# Altium schematic sheet PS.SchDoc
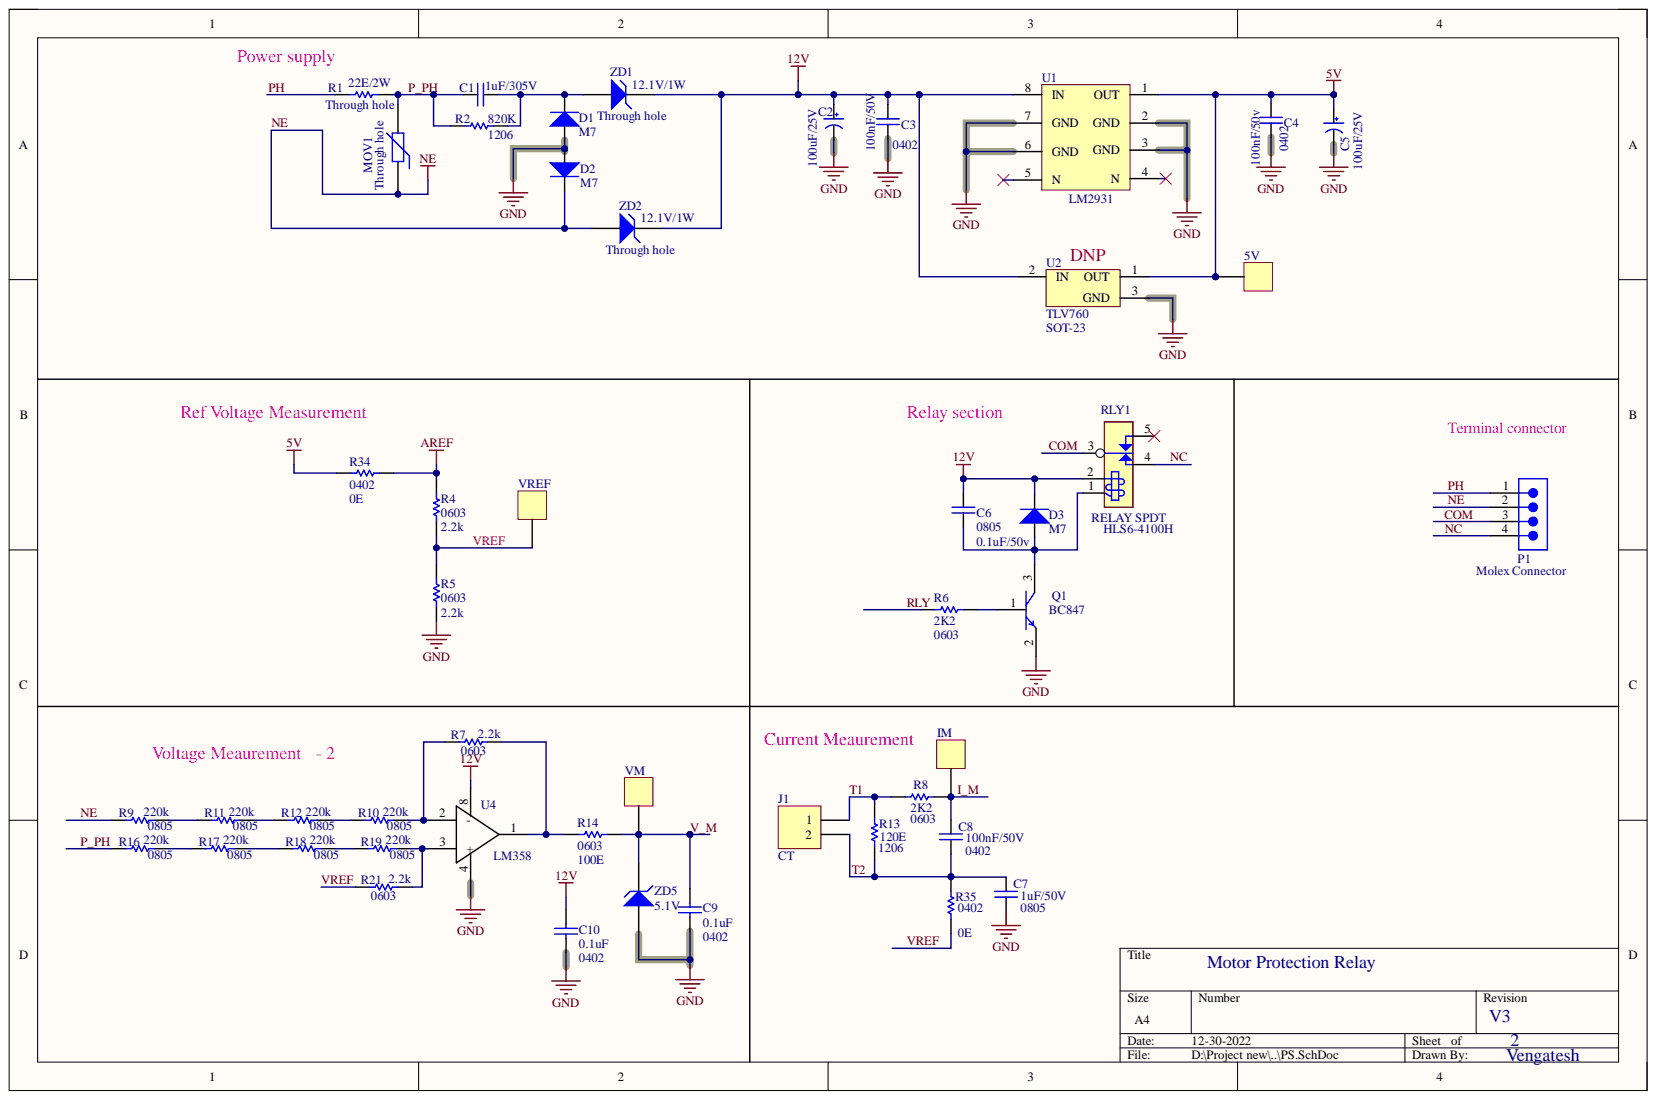
[diagram p0: PS.SchDoc]

## Parts
5V  Test pad  [Test Pad]  (value not drawn)
C1  value 1uF/305V  [Capacitor Y2-22.5mm_01]  (hidden comment: Cap)
C2  value 100uF/25V  [CAPPR2.5-6.3x7]  (hidden comment: Electrolytic Capacitor)
C3  value 100nF/50V  Package: 0402  [C_0402]  (hidden comment: Cap)
C4  value 100nF/50v  Package: 0402  [C_0402]  (hidden comment: Cap)
C5  value 100uF/25V  [CAPPR2.5-6.3x7]  (hidden comment: Electrolytic Capacitor)
C6  value 0.1uF/50v  Package: 0805  [C_0805]  (hidden comment: Cap)
C7  value 1uF/50V  Package: 0805  [0805-rc]  (hidden comment: Cap)
C8  value 100nF/50V  Package: 0402  [C_0402]  (hidden comment: Cap)
C9  value 0.1uF  Package: 0402  [C_0402]  (hidden comment: Cap)
C10  value 0.1uF  Package: 0402  [C_0402]  (hidden comment: Cap)
D1  value M7  [SMA-DIODE]  (hidden comment: diode)
D2  value M7  [SMA-DIODE]  (hidden comment: diode)
D3  value M7  [SMA-DIODE]  (hidden comment: diode)
IM  Test pad  [Test Pad]  (value not drawn)
J1  CT  [Molex_SPOX-5267_22-03-5025_02x2.54mm_Straight]
MOV1  MOV  Package: Through hole  [Mov - 3 Pin]
P1  645004114822  [645004114822]  (value not drawn)
Q1  value BC847  MFG no: BC847  [SOT-23]  (hidden comment: Transistor)
R1  value 22E/2W  Package: Through hole  [RESISTOR 1/2W]  (hidden comment: Res1)
R2  value 820K  Package: 1206  [R_1206]  (hidden comment: Res1)
R4  value 2.2k  Package: 0603  [0603-RC]  (hidden comment: 47R 5% 0805)
R5  value 2.2k  Package: 0603  [0603-RC]  (hidden comment: 47R 5% 0805)
R6  value 2K2  Package: 0603  [0603-RC]  (hidden comment: Res1)
R7  value 2.2k  Package: 0603  [0603-RC]
R8  value 2K2  [0603-RC]
R9  value 220k  Package: 0805  [R_0805]
R10  value 220k  Package: 0805  [R_0805]
R11  value 220k  Package: 0805  [R_0805]
R12  value 220k  Package: 0805  [R_0805]
R13  value 120E  Package: 1206  [1206-RC]
R14  value 100E  Package: 0603  [0603-RC]
R16  value 220k  Package: 0805  [R_0805]
R17  value 220k  Package: 0805  [R_0805]
R18  value 220k  Package: 0805  [R_0805]
R19  value 220k  Package: 0805  [R_0805]
R21  value 2.2k  Package: 0603  [0603-RC]
R34  value 0E  Package: 0402  [R_0402]  (hidden comment: 47R 5% 0805)
R35  value 0E  Package: 0402  [R_0402]
RLY1  RELAY SPDT  MFG no: HLS6-4100H  Package: SPDT  [CRST4101]
U1  LM2931  [SOIC-8]
U2  value TLV760  [SOT-23]  (hidden comment: Regulator)
U4  value LM358  [SOIC-8]  (hidden comment: op-amp)
VM  Test pad  [Test Pad]  (value not drawn)
VREF  Test pad  [Test Pad]  (value not drawn)
ZD1  value 12.1V/1W  Pacakge: Through hole  [DO-41 - SMD]  (hidden comment: 6V2)
ZD2  12.1  value 12.1V/1W  Pacakge: Through hole  [DO-41 - SMD]
ZD5  value 5.1V  [SOD-123]  (hidden comment: zener diode)

## Nets
5V: 5V.1 C4.2 C5.1 R34.1 U1.1(OUT) U2.1
12V: C2.1 C3.2 C6.2 C10.2 D3.2 RLY1.2 U1.8(IN) U2.2 U4.8(-) ZD1.K ZD2.K
AREF: R4.1 R34.2
GND: C2.2 C3.1 C4.1 C5.2 C7.1 C9.1 C10.1 D1.1 D2.1 Q1.2 R5.2 U1.2(GND) U1.3(GND) U1.6(GND) U1.7(GND) U2.3 U4.4(+) ZD5.1
COM: P1.3 RLY1.3
I_M: C8.2 IM.1 R8.1
NC: P1.4 RLY1.4
NE: D2.2 MOV1.1 P1.2 R9.2 ZD2.A
P_PH: C1.1 MOV1.2 R1.2 R2.1 R16.2
PH: P1.1 R1.1
RLY: R6.1
V_M: C9.2 R14.1 VM.1 ZD5.2
VREF: R4.2 R5.1 R21.2 R35.1 VREF.1
NetC1_2: C1.2 D1.2 R2.2 ZD1.A
NetC6_1: C6.1 D3.1 Q1.3 RLY1.1
NetC7_2: C7.2 C8.1 J1.2 R13.2 R35.2
NetJ1_1: J1.1 R8.2 R13.1
NetQ1_1: Q1.1 R6.2
NetR7_1: R7.1 R14.2 U4.1
NetR7_2: R7.2 R10.1 U4.2
NetR9_1: R9.1 R11.2
NetR10_2: R10.2 R12.1
NetR11_1: R11.1 R12.2
NetR16_1: R16.1 R17.2
NetR17_1: R17.1 R18.2
NetR18_1: R18.1 R19.2
NetR19_1: R19.1 R21.1 U4.3
T1:
T2:

Not connected: RLY1 5; U1 4(N) 5(N)

## Library data of the parts
5V IM VM VREF = Header 1x1: Component Kind: Standard; Library Name: Headers.SchLib
C1 = Cap_1: CAPACITOR, Through hole, B32923H3105M000, 1uF or 0.68uF, X2, B32923H Series, 305 V, Polypropylene, W-10.5 mm H-16.5 mm L-26.5 mm; Block: Power supply; Drawing no: 4218280000601000; MFG no: TDK-B32921; Soldering points: 2; Component Kind: Standard; LatestRevisionDate: 17-Jul-2002; LatestRevisionNote: Re-released for DXP Platform.; PackageDescription: Radial Cap, Thru-Hole; 2 Leads; 0.3 in Pin Spacing; PackageReference: RAD-0.3; Published: 8-Jun-2000; Signal Integrity: Cap; Simulation: CAP; Library Name: MPR.SchLib; Publisher: Altium Limited
C2 = ELECTROLYTIC: ELEC CAPACITOR THROUGH HOLE, 100UF/25V, SIZE-Diameter 5mm,Height 11MM; Block: Power supply; Drawing no: 4218260001200000; MFG: Vishay; Soldering points: 2; Component Kind: Standard; Package: Through hole; Library Name: MPR.SchLib
C3 C4 = Cap_1: Capacitor; Block: Power supply; Drawing no: 4922490001005000; Soldering points: 2; Component Kind: Standard; LatestRevisionDate: 17-Jul-2002; LatestRevisionNote: Re-released for DXP Platform.; PackageReference: RAD-0.3; Published: 8-Jun-2000; Signal Integrity: Cap; Simulation: CAP; Library Name: MPR.SchLib; Publisher: Altium Limited
C5 = ELECTROLYTIC: ELECTROLYTIC CAPACITOR 100UF/16V, 105 C; Block: Power supply; Drawing no: 4218260000300000; MFG: Samwha /Keltron; Soldering points: 2; Component Kind: Standard; Package: Through hole; Library Name: MPR.SchLib
C6 = Cap_1: CAPACITOR SMD PACKAGE 0.1UF,0805,X7R,50V; Block: Relay section; Drawing no: 4218290000100000; Soldering points: 2; Value: 0.1uF/50v; Component Kind: Standard; LatestRevisionDate: 17-Jul-2002; LatestRevisionNote: Re-released for DXP Platform.; PackageReference: RAD-0.3; Published: 8-Jun-2000; Signal Integrity: Cap; Simulation: CAP; Library Name: MPR.SchLib; Publisher: Altium Limited
C7 C8 = Cap_1: Capacitor, Ceramic, 100nF, 50V, 10% Tolerance, MLCC - SMD/SMT 0402 Package, PN: GRM155R71H104KE14D; Block: Current measurement; Drawing no: 4218290000120000; Soldering points: 2; Component Kind: Standard; LatestRevisionDate: 17-Jul-2002; LatestRevisionNote: Re-released for DXP Platform.; PackageReference: RAD-0.3; Published: 8-Jun-2000; Signal Integrity: Cap; Simulation: CAP; Library Name: MPR.SchLib; Publisher: Altium Limited
C9 C10 = Cap: Capacitor, Ceramic, 100nF, 50V, 10% Tolerance, MLCC - SMD/SMT 0402 Package, PN: GRM155R71H104KE14D; Block: Voltage measurement; Drawing no: 4218290000120000; Soldering points: 2; Component Kind: Standard; LatestRevisionDate: 17-Jul-2002; LatestRevisionNote: Re-released for DXP Platform.; Library Name: Miscellaneous Devices.IntLib; PackageDescription: Radial Cap, Thru-Hole; 2 Leads; 0.3 in Pin Spacing; Published: 8-Jun-2000; Signal Integrity: Cap; Simulation: CAP; Publisher: Altium Limited
  C9: PackageReference: 1206
D1 D2 = diode: DIODE, STANDARD RECTIFIER, Vrrm1000V, If1A, Vf1.1V, Ifsm>25A, M7/S1MF,  SMA/DO-214AC; Block: Power supply; Drawing no: 4218230000200000; MFG: Diotech/ Onsemi; Soldering points: 2; Component Kind: Standard; MFG no: M7/S1MF; Package: DO-214AC; Library Name: MPR.SchLib
D3 = diode: DIODE, STANDARD RECTIFIER, Vrrm1000V, If1A, Vf1.1V, Ifsm>25A, M7/S1MF,  SMA/DO-214AC; Block: Relay section; Drawing no: 4218230000200000; MFG: Diotech/Onsemi; Soldering points: 2; Component Kind: Standard; MFG no: M7/S1MF; Package: DO-214AC; Library Name: MPR.SchLib
J1 = Header 2: Header, 2-Pin; Block: Current measurement; Soldering points: 2; Value: Conn; Component Kind: Standard; LatestRevisionDate: 17-Jul-2002; LatestRevisionNote: Re-released for DXP Platform.; Library Name: Miscellaneous Connectors.IntLib; Package: Through hole; Signal Integrity: Connector; Publisher: Altium Limited
MOV1 = Res Varistor: Varistor,TH, 320 VAC, 420 VDC, StandarD Series, 840 V, Disc 10mm, REF PART:B72210S0321K101; Block: Power supply; Drawing no: 4923650000003000; Soldering points: 2; Code_IPC: RESC2012; Component Kind: Standard; LatestRevisionDate: 29-May-2009; LatestRevisionNote: IPC-7351 Footprint Added.; PackageDescription: Chip Resistor 0805 Case Size; PackageReference: 6-0805; PackageVersion: Mar-2003; Published: 24-Mar-1999; Value: MOV; Library Name: MPR.SchLib; Publisher: Altium Limited
P1 = 645004114822: WR-WTB THT Male Vertical Locking Header, pitch 3.96mm, 4pins; Manufacturer: Wurth Elektronik; Contact Resistance: 20mΩ; Rated Current: 7A; Withstanding Voltage: 1500VAC; Working Voltage: 250VAC; Category: Wire-to-Board Connectors; Component Kind: Standard; ComponentLink1Description: Website Link; ComponentLink1URL: https://www.we-online.com/redexpert/article/645004114822?ad; ComponentLink2Description: Datasheet Link; ComponentLink2URL: https://www.we-online.com/redexpert/spec/645004114822?ad; Gender: Male; Height: 10.65mm; Length: 15.84mm; Library Name: Altium_WR-WTB (rev22a) (1).IntLib; Manufacturer Part Number: 645004114822; Match Code: WR-WTB; Mount: Through Hole; Number of Pins: 4; Number of Rows: 1; Operating Temperature Max: 105°C; Operating Temperature Min: -40°C; Orientation: Vertical; Packaging: Bag; Pitch: 3.96mm; Width: 10.75mm
Q1 = BC896: TRANSISTOR, NPN, BJT, BC847, Vce 45V, Ic 100mA, SMD, SOT23; Block: Relay section; Drawing no: 4830830000000000; Soldering points: 3; Component Kind: Standard; Package: SOT23; Library Name: MPR.SchLib
R1 = Res1: WIRE WOUND RESISTOR 22E 2W, HTR, HIA SERIES, H2 TYPE, +-5%,THROUGH HOLE; Block: power supply; Drawing No: 4923700000000000; Soldering points: 2; Component Kind: Standard; MFG: HTR; Signal Integrity: Res; Simulation: RESISTOR; Library Name: MPR.SchLib
R2 = Res1: SMD RESISTOR 820K SMD1206 +-1%; Block: power supply; Drawing No: 4218291600000000; Component Kind: Standard; Signal Integrity: Res; Simulation: RESISTOR; Library Name: MPR.SchLib
R4 R5 = RES_47R_0805_5%: RESISTOR, CHIP TYPE, 4.7k, +/-1% Tolerance, 0402 PACKAGE; Manufacturer: Panasonic; PartNumber: ERJ-P06J470V; Block: Ref voltage measurement; Drawing no: EICRES4K71T0402; Power: 0.5W; Soldering points: 2; Component Kind: Standard; ComponentLink1Description: Datasheet; ComponentLink1URL: https://industrial.panasonic.com/cdbs/www-data/pdf/RDA0000/AOA0000C243.pdf; Library Name: Resistors-0805.SchLib; Mounting Technology: Surface Mount; PackageReference: 0805; Tolerance: 5%
R6 = Res1: RESISTOR, Chip Type, 4K7, +/-1%, SMD, 0402 Package; Block: Relay section; Drawing No: 4218300004210000; Soldering points: 2; Component Kind: Standard; Signal Integrity: Res; Simulation: RESISTOR; Library Name: MPR.SchLib
R7 = Res1: RESISTOR, Chip Type, 2.2k, +/-1%, SMD, 0805 Package; Block: Voltage measurement; Drawing No: 4218300000900000; Soldering points: 2; Component Kind: Standard; Library Name: Miscellaneous Devices.IntLib; Signal Integrity: Res; Simulation: RESISTOR
R8 = Res1: RESISTOR, Chip Type, 2.2k, +/-1%, SMD, 0402 Package; Block: Current measurement; Drawing No: 4218300003910000; Soldering points: 2; Component Kind: Standard; Library Name: Miscellaneous Devices.IntLib; Package: 0603; Signal Integrity: Res; Simulation: RESISTOR
R9-R12 R16-R19 = Res1: Chip resistor,200k,0402; Block: Voltage measurement; Soldering points: 2; Component Kind: Standard; Library Name: Miscellaneous Devices.IntLib; Signal Integrity: Res; Simulation: RESISTOR
R13 = Res1: RESISTOR, CHIP TYPE,120E, +/-1%, SMD, 0805; Block: Current measurement; Drawing No: 4218300000120000; Soldering points: 2; Component Kind: Standard; Library Name: Miscellaneous Devices.IntLib; Signal Integrity: Res; Simulation: RESISTOR
R14 = Res1: RESISTOR, CHIP TYPE, 100E, +/-5%, SMD, 1/16W 0402 PACKAGE; Block: Current measurement; Drawing No: EICRESX1005TO4O2; Soldering points: 2; Component Kind: Standard; Library Name: Miscellaneous Devices.IntLib; Signal Integrity: Res; Simulation: RESISTOR
R21 = Res1: RESISTOR, CHIP TYPE, 2K2, +/-1%, SMD, 0805; Block: Voltage measurement; Drawing No: 4218300000900000; Soldering points: 2; Component Kind: Standard; Library Name: Miscellaneous Devices.IntLib; Signal Integrity: Res; Simulation: RESISTOR
R34 = RES_47R_0805_5%: RESISTOR, CHIP TYPE, 0E, +/-1%, SMD, 0402 PACKAGE, PART: AC0402FR-070RL; PartNumber: ERJ-P06J470V; Block: power supply; Drawing no: 4922500010000000; MFG: YAgeo; Power: 0.5W; Soldering points: 2; Component Kind: Standard; ComponentLink1Description: Datasheet; ComponentLink1URL: https://industrial.panasonic.com/cdbs/www-data/pdf/RDA0000/AOA0000C243.pdf; Library Name: Resistors-0805.SchLib; MFG no: AC0402FR-070RL; Tolerance: 5%
R35 = Res1: Resistor; Block: Current measurement; Soldering no: 2; Component Kind: Standard; Library Name: Miscellaneous Devices.IntLib; Signal Integrity: Res; Simulation: RESISTOR
RLY1 = RELAY SPDT_2: RELAY, SPDT, 12V DC COIL, 1 FORM C, 10A, THROUGH HOLE, PART: JQ-SS-112D; Block: Relay section; Drawing No: 4830900000100000; MFG: GOODSKY/OMRON/PANASONIC; Soldering points: 5; Component Kind: Standard; Library Name: ILM_RF_PS.SCHLIB
U1 = LM2931: IC, LM2931AD-5.0R2G LDO, 14V-40V in, 15mV Dropout, 5V/100mA out, SOIC-8; Block: Power supply; Drawing no: 4899030000003000; MFG: Onsemi; Soldering points: 8; Component Kind: Standard; MFG no: LM2931AD; Package: SOIC-8; Value: LM2931; Library Name: MPR.SchLib
U2 = TLV760: LDO,5V,100mA,SOT23,TI make,TLV76050DBZR; Block: Power supply; Drawing no: 00101E91LDOV7605; Soldering points: 3; Component Kind: Standard; MFG: TI; MFG no: TLV76050DBZR; Package: SOT-23; Library Name: mpr.SchLib
U4 = op-amp: IC, OPAMP, LM358, DUAL OPERATIONAL AMPLIFIER 1 MHZ, SMD, 8-SOIC; Block: Voltage measurement; Drawing no: 4923450000200100; MFG no: LM358DR2; Soldering points: 8; Component Kind: Standard; Package: SOIC-8; Library Name: mpr.SchLib
ZD1 ZD2 = D Zener: Block: Power supply; Drawing no: 00101E91ZED00062; MFG: Onsemi/Fairchild; MFG no: 1N4735A; Soldering points: 2; Component Kind: Standard; LatestRevisionDate: 17-Jul-2002; LatestRevisionNote: Re-released for DXP Platform.; PackageDescription: Diode, Thru-Hole; 2 Leads; 0.7 in Pin Spacing; PackageReference: DIODE-0.7; Published: 8-Jun-2000; Signal Integrity: D Zener; Simulation: ZENER; Library Name: MPR.SchLib; Publisher: Altium Limited
ZD5 = D Zener: DIODE, ZENER DIODE, 5.1V, 375mW, +/-5%, SMD, SOD123, REF PART -BZT52H-C5V1; Block: Power supply; Drawing no: 4400200900004100; Soldering points: 2; Component Kind: Standard; LatestRevisionDate: 17-Jul-2002; LatestRevisionNote: Re-released for DXP Platform.; MFG no: BZT52H-C5V1; Pacakge: SOD123; PackageDescription: Diode, Thru-Hole; 2 Leads; 0.7 in Pin Spacing; PackageReference: DIODE-0.7; Published: 8-Jun-2000; Signal Integrity: D Zener; Simulation: ZENER; Library Name: MPR.SchLib; Publisher: Altium Limited
